# Altium schematic sheet CPU.SchDoc (continued)

## Library data of the parts
C5-C7 C10-C12 C16 C24 = CL10B104KO8NNNC: 16V 100nF X7R ±10% 0603 Multilayer Ceramic Capacitors MLCC - SMD/SMT ROHS; Manufacturer: Samsung Electro-Mechanics; Case Code (Imperial): 0603; Case Code (Metric): 1608; Case/Package: 0603; Category: Ceramic Capacitors; China RoHS: Compliant; Component Kind: Standard; ComponentLink1Description: https://datasheet.octopart.com:443/CL10B104KO8NNNC-Samsung-Electro-Mechanics-datasheet-136482305.pdf?src-supplier=IHS; ComponentLink1URL: https://datasheet.octopart.com:443/CL10B104KO8NNNC-Samsung-Electro-Mechanics-datasheet-136482305.pdf?src-supplier=IHS; ComponentLink2Description: https://datasheet.octopart.com/CL10B104KO8NNNC-Samsung-Electro-Mechanics-datasheet-8324245.pdf; ComponentLink2URL: https://datasheet.octopart.com/CL10B104KO8NNNC-Samsung-Electro-Mechanics-datasheet-8324245.pdf; ComponentLink3Description: https://datasheet.octopart.com/CL10B104KO8NNNC-Samsung-Electro-Mechanics-datasheet-97040617.pdf; ComponentLink3URL: https://datasheet.octopart.com/CL10B104KO8NNNC-Samsung-Electro-Mechanics-datasheet-97040617.pdf; ComponentLink4Description: https://datasheet.octopart.com:443/CL10B104KO8NNNC-Samsung-Electro-Mechanics-datasheet-116890973.pdf?src-supplier=Future+Electronics; ComponentLink4URL: https://datasheet.octopart.com:443/CL10B104KO8NNNC-Samsung-Electro-Mechanics-datasheet-116890973.pdf?src-supplier=Future+Electronics; ComponentLink5Description: https://datasheet.octopart.com:443/CL10B104KO8NNNC-Samsung-Electro-Mechanics-datasheet-81461844.pdf?src-supplier=Future+Electronics; ComponentLink5URL: https://datasheet.octopart.com:443/CL10B104KO8NNNC-Samsung-Electro-Mechanics-datasheet-81461844.pdf?src-supplier=Future+Electronics; ComponentLink6Description: https://datasheet.octopart.com:443/CL10B104KO8NNNC-Samsung-datasheet-42770384.pdf?src-supplier=Future+Electronics; ComponentLink6URL: https://datasheet.octopart.com:443/CL10B104KO8NNNC-Samsung-datasheet-42770384.pdf?src-supplier=Future+Electronics; ComponentLink7Description: https://datasheet.octopart.com:443/CL10B104KO8NNNC-Samsung-datasheet-26589157.pdf?src-supplier=Future+Electronics; ComponentLink7URL: https://datasheet.octopart.com:443/CL10B104KO8NNNC-Samsung-datasheet-26589157.pdf?src-supplier=Future+Electronics; ComponentLink8Description: https://datasheet.octopart.com:443/CL10B104KO8NNNC-Samsung-Electro-Mechanics-datasheet-149136789.pdf?src-supplier=Future+Electronics; ComponentLink8URL: https://datasheet.octopart.com:443/CL10B104KO8NNNC-Samsung-Electro-Mechanics-datasheet-149136789.pdf?src-supplier=Future+Electronics; ComponentLink9Description: https://datasheet.octopart.com:443/CL10B104KO8NNNC-Samsung-datasheet-8326407.pdf?src-supplier=TME; ComponentLink9URL: https://datasheet.octopart.com:443/CL10B104KO8NNNC-Samsung-datasheet-8326407.pdf?src-supplier=TME; ComponentLink10Description: https://datasheet.octopart.com:443/CL10B104KO8NNNC-Samsung-Electro-Mechanics-datasheet-11890603.pdf?src-supplier=Arrow+Electronics; ComponentLink10URL: https://datasheet.octopart.com:443/CL10B104KO8NNNC-Samsung-Electro-Mechanics-datasheet-11890603.pdf?src-supplier=Arrow+Electronics; Contact Plating: Tin; Depth: 800µm; Dielectric: X7R; Dielectric Material: Ceramic; DigiKey: 1276-1005-1-ND; Height: 800µm; Lead Free: Lead Free; Length: 1.6mm; Library Name: Ceramic Capacitors.IntLib; Lifecycle Status: Production; Material: Ceramic; Max Operating Temperature: 125°C; Min Operating Temperature: -55°C; Mounting Technology: Surface Mount; Number of Terminals: 2; P/N: CL10B104KO8NNNC; Pricing: 1=0.1, 10=0.026, 50=0.0172, 100=0.0146, 500=0.0103, 1000=0.00899 (USD); Radiation Hardening: No; REACH SVHC: Yes; RoHS: Compliant; Schedule B: 8532240020, 8532240020|8532240020, 8532240020|8532240020|8532240020, 8532240020|8532240020|8532240020|8532240020; Stock: 2399591; Supplier: DigiKey; Thickness: 889µm; Tolerance: 10%; Voltage: 16V; Voltage Rating: 16V; Voltage Rating (DC): 16V; Width: 800µm
C8 C9 = CL10C180JB81PNC: Ceramic Capacitor, Multilayer, Ceramic, 50V, 5% +Tol, 5% -Tol, C0G, 0+-30ppm/Celcius TC, 18pF, Surface Mount, 0603 inch; Manufacturer: Samsung Electro-Mechanics; Temperature Coefficient: 30ppm/°C; Case Code (Imperial): 0603; Case Code (Metric): 1608; Case/Package: 0603; Category: Ceramic Capacitors; China RoHS: Compliant; Component Kind: Standard; ComponentLink1Description: https://datasheet.octopart.com:443/CL10C180JB81PNC-Samsung-Electro-Mechanics-datasheet-176142735.pdf?src-supplier=IHS; ComponentLink1URL: https://datasheet.octopart.com:443/CL10C180JB81PNC-Samsung-Electro-Mechanics-datasheet-176142735.pdf?src-supplier=IHS; ComponentLink2Description: https://datasheet.octopart.com:443/CL10C180JB81PNC-Samsung-datasheet-26669134.pdf?src-supplier=Future+Electronics; ComponentLink2URL: https://datasheet.octopart.com:443/CL10C180JB81PNC-Samsung-datasheet-26669134.pdf?src-supplier=Future+Electronics; ComponentLink3Description: https://datasheet.octopart.com:443/CL10C180JB81PNC-Samsung-datasheet-12552822.pdf?src-supplier=Future+Electronics; ComponentLink3URL: https://datasheet.octopart.com:443/CL10C180JB81PNC-Samsung-datasheet-12552822.pdf?src-supplier=Future+Electronics; ComponentLink4Description: https://datasheet.octopart.com:443/CL10C180JB81PNC-Samsung-Electro-Mechanics-datasheet-156002628.pdf?src-supplier=Future+Electronics; ComponentLink4URL: https://datasheet.octopart.com:443/CL10C180JB81PNC-Samsung-Electro-Mechanics-datasheet-156002628.pdf?src-supplier=Future+Electronics; ComponentLink5Description: https://datasheet.octopart.com:443/CL10C180JB81PNC-Samsung-datasheet-37081328.pdf?src-supplier=Future+Electronics; ComponentLink5URL: https://datasheet.octopart.com:443/CL10C180JB81PNC-Samsung-datasheet-37081328.pdf?src-supplier=Future+Electronics; ComponentLink6Description: https://datasheet.octopart.com:443/CL10C180JB81PNC-Samsung-Electro-Mechanics-datasheet-11786236.pdf?src-supplier=Arrow+Electronics; ComponentLink6URL: https://datasheet.octopart.com:443/CL10C180JB81PNC-Samsung-Electro-Mechanics-datasheet-11786236.pdf?src-supplier=Arrow+Electronics; Depth: 800µm; Dielectric: C0G; Dielectric Material: Ceramic; DigiKey: 1276-2208-1-ND; Height: 800µm; Lead Free: Lead Free; Length: 1.6mm; Library Name: Ceramic Capacitors.IntLib; Lifecycle Status: Production; Material: Ceramic; Max Operating Temperature: 125°C; Min Operating Temperature: -55°C; Mounting Technology: Surface Mount; Number of Terminals: 2; P/N: CL10C180JB81PNC; Pricing: 1=0.1, 10=0.035, 50=0.0234, 100=0.02, 500=0.01428, 1000=0.01255 (USD); Radiation Hardening: No; Ratings: AEC-Q200; REACH SVHC: Yes; RoHS: Compliant; Schedule B: 8532240020, 8532240020|8532240020|8532240020|8532240020|8532240020; Stock: 34952; Supplier: DigiKey; Thickness: 889µm; Tolerance: 5%; Voltage Rating: 50V; Voltage Rating (DC): 50V; Width: 800µm
C13 C14 C17 = CL21A106KOQNNNE: 16V 10uF X5R ±10% 0805 Multilayer Ceramic Capacitors MLCC - SMD/SMT ROHS; Manufacturer: Samsung Electro-Mechanics; Case Code (Imperial): 0805; Case Code (Metric): 2012; Case/Package: 0805; Category: Ceramic Capacitors; China RoHS: Compliant; Component Kind: Standard; ComponentLink1Description: https://datasheet.octopart.com:443/CL21A106KOQNNNE-Samsung-Electro-Mechanics-datasheet-136031799.pdf?src-supplier=IHS; ComponentLink1URL: https://datasheet.octopart.com:443/CL21A106KOQNNNE-Samsung-Electro-Mechanics-datasheet-136031799.pdf?src-supplier=IHS; ComponentLink2Description: https://datasheet.octopart.com/CL21A106KOQNNNE-Samsung-Electro-Mechanics-datasheet-8324245.pdf; ComponentLink2URL: https://datasheet.octopart.com/CL21A106KOQNNNE-Samsung-Electro-Mechanics-datasheet-8324245.pdf; ComponentLink3Description: https://datasheet.octopart.com/CL21A106KOQNNNE-Samsung-Electro-Mechanics-datasheet-97040617.pdf; ComponentLink3URL: https://datasheet.octopart.com/CL21A106KOQNNNE-Samsung-Electro-Mechanics-datasheet-97040617.pdf; ComponentLink4Description: https://datasheet.octopart.com:443/CL21A106KOQNNNE-Samsung-Electro-Mechanics-datasheet-130012977.pdf?src-supplier=Future+Electronics; ComponentLink4URL: https://datasheet.octopart.com:443/CL21A106KOQNNNE-Samsung-Electro-Mechanics-datasheet-130012977.pdf?src-supplier=Future+Electronics; ComponentLink5Description: https://datasheet.octopart.com:443/CL21A106KOQNNNE-Samsung-Electro-Mechanics-datasheet-82614846.pdf?src-supplier=Future+Electronics; ComponentLink5URL: https://datasheet.octopart.com:443/CL21A106KOQNNNE-Samsung-Electro-Mechanics-datasheet-82614846.pdf?src-supplier=Future+Electronics; ComponentLink6Description: https://datasheet.octopart.com:443/CL21A106KOQNNNE-Samsung-Electro-Mechanics-datasheet-81462122.pdf?src-supplier=Future+Electronics; ComponentLink6URL: https://datasheet.octopart.com:443/CL21A106KOQNNNE-Samsung-Electro-Mechanics-datasheet-81462122.pdf?src-supplier=Future+Electronics; ComponentLink7Description: https://datasheet.octopart.com:443/CL21A106KOQNNNE-Samsung-datasheet-48647542.pdf?src-supplier=Future+Electronics; ComponentLink7URL: https://datasheet.octopart.com:443/CL21A106KOQNNNE-Samsung-datasheet-48647542.pdf?src-supplier=Future+Electronics; ComponentLink8Description: https://datasheet.octopart.com:443/CL21A106KOQNNNE-Samsung-datasheet-26669228.pdf?src-supplier=Future+Electronics; ComponentLink8URL: https://datasheet.octopart.com:443/CL21A106KOQNNNE-Samsung-datasheet-26669228.pdf?src-supplier=Future+Electronics; ComponentLink9Description: https://datasheet.octopart.com:443/CL21A106KOQNNNE-Samsung-Electro-Mechanics-datasheet-149154152.pdf?src-supplier=Future+Electronics; ComponentLink9URL: https://datasheet.octopart.com:443/CL21A106KOQNNNE-Samsung-Electro-Mechanics-datasheet-149154152.pdf?src-supplier=Future+Electronics; ComponentLink10Description: https://datasheet.octopart.com:443/CL21A106KOQNNNE-Samsung-datasheet-22147434.pdf?src-supplier=TME; ComponentLink10URL: https://datasheet.octopart.com:443/CL21A106KOQNNNE-Samsung-datasheet-22147434.pdf?src-supplier=TME; Depth: 1.25mm; Dielectric: X5R; Dielectric Material: Ceramic; DigiKey: 1276-1096-1-ND; Height: 1.4mm; Lead Free: Lead Free; Length: 2mm; Library Name: Ceramic Capacitors.IntLib; Lifecycle Status: Production; Material: Ceramic; Max Operating Temperature: 85°C; Min Operating Temperature: -55°C; Mounting Technology: Surface Mount; Number of Terminals: 2; P/N: CL21A106KOQNNNE; Pricing: 1=0.08, 10=0.021, 50=0.02, 100=0.0192, 500=0.0144, 1000=0.0128 (USD); Radiation Hardening: No; REACH SVHC: Yes; RoHS: Compliant; Schedule B: 8532240020, 8532240020|8532240020|8532240020|8532240020|8532240020|8532240020|8532240020|8532240020|8532240020|8532240020|8532240020|8532240020|8532240020|8532240020|8532240020|8532240020|8532240020|8532240020|8532240020|8532240020|8532240020|8532240020|8532240020|85; Stock: 1352697; Supplier: DigiKey; Thickness: 1.397mm; Tolerance: 10%; Voltage: 16V; Voltage Rating: 16V; Voltage Rating (DC): 16V; Width: 1.25mm
C15 = CL10B105KP8NNNC: Multilayer Ceramic Capacitor, 1 uF, 10 V, ± 10%, X7R, 0603 [1608 Metric]; Manufacturer: Samsung; Material: 4241ConductorMaterial; Voltage Rating: 10V; Case Code (Imperial): 0603; Case Code (Metric): 1608; Case/Package: 0603; Category: Ceramic Capacitors; Component Kind: Standard; Construction: Flat; Depth: 0.8mm; Dielectric: X7R; DigiKey: 1276-1946-1-ND; Height: 0.8mm; Lead Free: Lead Free; Length: 1.6mm; Library Name: Ceramic Capacitors.IntLib; Max Operating Temperature: 125°C; Min Operating Temperature: -55°C; Mounting Technology: Surface Mount; P/N: CL10B105KP8NNNC; Package Quantity: 1; Packaging: Tape and Reel; Pricing: 1=0.1, 10=0.026, 50=0.0146, 100=0.0114, 500=0.00858, 1000=0.00674 (USD); Radiation Hardening: No; RoHS: Yes; RoHS Compliant: Yes; Series: CL; Stock: 7012743; Supplier: Digikey; Thickness: 0.035inch; Tolerance: 10%; Voltage Rating (DC): 10V; Width: 0.031inch
MICRO_SD_CARD = TFP09-2-12B: -25℃~+85℃ 1.85mm Connector and Ejector MicroSD Card (TF Card) Push-Push SMD SD Card / Memory Card Connector ROHS; Component Kind: Standard; Library Name: Connectors.SchLib; P/N: TFP09-2-12B
PROG = TC2050_Full: “No Legs” 10-pin Plug-of-Nails™ Cable fitted with a 10-pin 0.1″ pitch ribbon connector.; Assembly: DNP; Component Kind: Standard; Library Name: Programming Connectors.IntLib
Q1 = PMV30XPAR: Transistor Mosfet P-ch 20V 4.9A 3-PIN SOT-23 T/r; Manufacturer: Nexperia; Manufacturer Lifecycle Status: RELEASED FOR SUPPLY; Drain to Source Resistance: 38mΩ; Max Power Dissipation: 8.3W; Number of Elements: 1; Category: MOSFETs; Component Kind: Standard; ComponentLink1Description: https://datasheet.octopart.com:443/PMV30XPAR-Nexperia-datasheet-180924910.pdf?src-supplier=IHS; ComponentLink1URL: https://datasheet.octopart.com:443/PMV30XPAR-Nexperia-datasheet-180924910.pdf?src-supplier=IHS; ComponentLink2Description: https://datasheet.octopart.com:443/PMV30XPAR-Nexperia-datasheet-138125170.pdf?src-supplier=Future+Electronics; ComponentLink2URL: https://datasheet.octopart.com:443/PMV30XPAR-Nexperia-datasheet-138125170.pdf?src-supplier=Future+Electronics; Contact Plating: Tin; Continuous Drain Current (ID): 4.9A; DigiKey: 1727-PMV30XPARCT-ND; Library Name: Mosfet.IntLib; Lifecycle Status: Production; Max Operating Temperature: 175°C; Min Breakdown Voltage: 20V; Min Operating Temperature: -55°C; Number of Terminals: 3; P/N: PMV30XPAR; Pricing: 1=0.42, 10=0.297, 100=0.1612, 500=0.1604, 1000=0.14598 (USD); REACH SVHC: Yes; RoHS: Compliant; Stock: 10935; Supplier: DigiKey
Q2 = MMBT2222ALT1G: Small Signal Bipolar Transistor, 0.6A I(C), 30V V(BR)CEO, 1-Element, NPN, Silicon, TO-236; Manufacturer: ON Semiconductor; DC Current Gain-Min (hFE): 75; Frequency: 250MHz; Max Collector Current: 600mA; Number of Elements: 1; Package Body Material: Plastic; Power Dissipation-Max (Abs): 225mW; Qualification Status: Not Qualified; Time @ Peak Reflow Temperature-Max (s): 40s; Transistor Application: SWITCHING; Turn-off Time-Max (toff): 285ns; Turn-on Time-Max (ton): 35ns; Category: BJTs; Collector-emitter Voltage-Max: 30V; Component Kind: Standard; ComponentLink1Description: https://datasheet.ciiva.com:443/pdfs/VipMasterIC/IC/ONSM/ONSM-S-A0002809824/ONSM-S-A0002809824-1.pdf?src-supplier=IHS+Markit; ComponentLink1URL: https://datasheet.ciiva.com:443/pdfs/VipMasterIC/IC/ONSM/ONSM-S-A0002809824/ONSM-S-A0002809824-1.pdf?src-supplier=IHS+Markit; ComponentLink2Description: https://datasheet.ciiva.com/976/mmbt2222lt1-d-976368.pdf?src-supplier=Digikey; ComponentLink2URL: https://datasheet.ciiva.com/976/mmbt2222lt1-d-976368.pdf?src-supplier=Digikey; ComponentLink3Description: https://datasheet.ciiva.com/976/mmbt2222lt1-d-976369.pdf?src-supplier=Digikey; ComponentLink3URL: https://datasheet.ciiva.com/976/mmbt2222lt1-d-976369.pdf?src-supplier=Digikey; ComponentLink4Description: https://datasheet.ciiva.com/976/mmbt2222lt1-d-976370.pdf?src-supplier=Digikey; ComponentLink4URL: https://datasheet.ciiva.com/976/mmbt2222lt1-d-976370.pdf?src-supplier=Digikey; ComponentLink5Description: https://datasheet.ciiva.com/4721/mmbt2222lt1-d-80103-4721468.pdf?src-supplier=Mouser; ComponentLink5URL: https://datasheet.ciiva.com/4721/mmbt2222lt1-d-80103-4721468.pdf?src-supplier=Mouser; ComponentLink6Description: https://datasheet.ciiva.com/11198/mmbt2222lt1-d-11198974.pdf?src-supplier=Avnet; ComponentLink6URL: https://datasheet.ciiva.com/11198/mmbt2222lt1-d-11198974.pdf?src-supplier=Avnet; ComponentLink7Description: https://datasheet.ciiva.com/11201/mmbt2222lt1-d-11201758.pdf?src-supplier=Avnet; ComponentLink7URL: https://datasheet.ciiva.com/11201/mmbt2222lt1-d-11201758.pdf?src-supplier=Avnet; ComponentLink8Description: https://datasheet.ciiva.com/15224/getdatasheetpartid-91924-15224358.pdf?src-supplier=Verical; ComponentLink8URL: https://datasheet.ciiva.com/15224/getdatasheetpartid-91924-15224358.pdf?src-supplier=Verical; DigiKey: MMBT2222ALT1GOSTR-ND; JEDEC-95 Code: TO-236; JESD-30 Code: R-PDSO-G3; JESD-609 Code: e3; Library Name: Transistor.IntLib; Max Operating Temperature: 150°C; Moisture Sensitivity Level: 1; P/N: MMBT2222ALT1G; Package Shape: Rectangular; Package Style: SMALL OUTLINEMeter; Peak Reflow Temperature (Cel): 260°C; Pins: 3; Polarity/Channel Type: NPN; Pricing: 1=0.14, 10=0.085, 100=0.0523, 500=0.03782, 1000=0.03311 (USD); Reach SVHC Compliant: Yes; RoHS: Yes; RoHS Compliant: Yes; Stock: 84926; Supplier: Digikey; Surface Mount: Yes; Terminal Finish: Tin; Terminal Form: Gull Wing; Terminal Position: DUAL; Transistor Element Material: SILICON
R1 = ERJ3EKF3300V: RES SMD 330 OHM 1% 1/10W 0603; Manufacturer: Panasonic; Max Power Dissipation: 100mW; Resistance: 330R; Temperature Coefficient: 100ppm/°C; Voltage Rating: 75V; Case Code (Imperial): 0603; Case Code (Metric): 1608; Case/Package: 0603; Component Kind: Standard; ComponentLink1Description: https://datasheet.ciiva.com/48531/aoa0000ce2-48531001.pdf?src-supplier=Avnet; ComponentLink1URL: https://datasheet.ciiva.com/48531/aoa0000ce2-48531001.pdf?src-supplier=Avnet; ComponentLink2Description: https://datasheet.ciiva.com/22721/426256-22721099.pdf?src-supplier=Element14; ComponentLink2URL: https://datasheet.ciiva.com/22721/426256-22721099.pdf?src-supplier=Element14; ComponentLink3Description: https://datasheet.ciiva.com/46373/1678782-46373899.pdf?src-supplier=Element14; ComponentLink3URL: https://datasheet.ciiva.com/46373/1678782-46373899.pdf?src-supplier=Element14; ComponentLink4Description: https://datasheet.ciiva.com/7886/aoa0000ce2-7886729.pdf?src-supplier=Element14; ComponentLink4URL: https://datasheet.ciiva.com/7886/aoa0000ce2-7886729.pdf?src-supplier=Element14; ComponentLink5Description: https://datasheet.ciiva.com/9117/aoa0000ce2-9117132.pdf?src-supplier=Avnet; ComponentLink5URL: https://datasheet.ciiva.com/9117/aoa0000ce2-9117132.pdf?src-supplier=Avnet; ComponentLink6Description: https://datasheet.ciiva.com/46373/1678782-46373900.pdf?src-supplier=Element14; ComponentLink6URL: https://datasheet.ciiva.com/46373/1678782-46373900.pdf?src-supplier=Element14; Composition: Thick Film; Contact Plating: Tin; Depth: 0.8mm; DigiKey: P330HCT-ND; Features: Automotive AEC-Q200; Height: 0.45mm; Lead Free: Lead Free; Length: 1.6mm; Library Name: Resistors.IntLib; Max Operating Temperature: 155°C; Military Standard: Not; Min Operating Temperature: -55°C; Mount: Surface Mount; Number of Pins: 2; Number of Terminations: 2; P/N: ERJ3EKF3300V; Package Quantity: 5000; Packaging: Tape and Reel; Power Rating: 100mW; Pricing 1: 1=0.10000, 10=0.07000, 100=0.02840, 1000=0.01275, 2500=0.01106 (USD); Radiation Hardening: No; REACH SVHC: No SVHC; RoHS: Yes; Stock 1: 1950276; Supplier 1: Digi-Key; Termination: SMD/SMT; Tolerance: 1%; Voltage Rating (DC): 75V; Weight: 7.1E-05oz; Width: 0.8mm
R2 R7 R8 = ERJ-3EKF1002V: Res Thick Film 0603 10K Ohm 1% 1/10W ±100ppm/°C Molded SMD Punched Carrier T/R; Manufacturer: Panasonic; Max Power Dissipation: 100mW; Voltage Rating: 75V; Case Code (Imperial): 0603; Case/Package: 0603; Category: Chip SMD Resistors; China RoHS: Non-Compliant; Component Kind: Standard; ComponentLink1Description: https://datasheet.octopart.com:443/ERJ-3EKF1002V-Panasonic-datasheet-13266541.pdf?src-supplier=Newark; ComponentLink1URL: https://datasheet.octopart.com:443/ERJ-3EKF1002V-Panasonic-datasheet-13266541.pdf?src-supplier=Newark; ComponentLink2Description: https://datasheet.octopart.com:443/ERJ-3EKF1002V-Panasonic-datasheet-5313456.pdf?src-supplier=Newark; ComponentLink2URL: https://datasheet.octopart.com:443/ERJ-3EKF1002V-Panasonic-datasheet-5313456.pdf?src-supplier=Newark; ComponentLink3Description: https://datasheet.octopart.com:443/ERJ-3EKF1002V-Panasonic-datasheet-168681906.pdf?src-supplier=Newark; ComponentLink3URL: https://datasheet.octopart.com:443/ERJ-3EKF1002V-Panasonic-datasheet-168681906.pdf?src-supplier=Newark; ComponentLink4Description: https://datasheet.octopart.com:443/ERJ-3EKF1002V-Panasonic-datasheet-48891056.pdf?src-supplier=Farnell; ComponentLink4URL: https://datasheet.octopart.com:443/ERJ-3EKF1002V-Panasonic-datasheet-48891056.pdf?src-supplier=Farnell; ComponentLink5Description: https://datasheet.octopart.com:443/ERJ-3EKF1002V-Panasonic-datasheet-136608610.pdf?src-supplier=Farnell; ComponentLink5URL: https://datasheet.octopart.com:443/ERJ-3EKF1002V-Panasonic-datasheet-136608610.pdf?src-supplier=Farnell; ComponentLink6Description: https://datasheet.octopart.com:443/ERJ-3EKF1002V-Panasonic-datasheet-10025800.pdf?src-supplier=element14+APAC; ComponentLink6URL: https://datasheet.octopart.com:443/ERJ-3EKF1002V-Panasonic-datasheet-10025800.pdf?src-supplier=element14+APAC; ComponentLink7Description: https://datasheet.octopart.com:443/ERJ-3EKF1002V-Panasonic-datasheet-8853621.pdf?src-supplier=element14+APAC; ComponentLink7URL: https://datasheet.octopart.com:443/ERJ-3EKF1002V-Panasonic-datasheet-8853621.pdf?src-supplier=element14+APAC; ComponentLink8Description: https://datasheet.octopart.com:443/ERJ-3EKF1002V-Panasonic-datasheet-82288794.pdf?src-supplier=Future+Electronics; ComponentLink8URL: https://datasheet.octopart.com:443/ERJ-3EKF1002V-Panasonic-datasheet-82288794.pdf?src-supplier=Future+Electronics; ComponentLink9Description: https://datasheet.octopart.com:443/ERJ-3EKF1002V-Panasonic-datasheet-154766169.pdf?src-supplier=Master+Electronics; ComponentLink9URL: https://datasheet.octopart.com:443/ERJ-3EKF1002V-Panasonic-datasheet-154766169.pdf?src-supplier=Master+Electronics; ComponentLink10Description: https://datasheet.octopart.com:443/ERJ3EKF1002V-Panasonic-datasheet-138819429.pdf?src-supplier=Burklin+Elektronik; ComponentLink10URL: https://datasheet.octopart.com:443/ERJ3EKF1002V-Panasonic-datasheet-138819429.pdf?src-supplier=Burklin+Elektronik; ComponentLink11Description: https://datasheet.octopart.com/ERJ-3EKF1002V-Panasonic-datasheet-78375410.pdf; ComponentLink11URL: https://datasheet.octopart.com/ERJ-3EKF1002V-Panasonic-datasheet-78375410.pdf; ComponentLink12Description: https://datasheet.octopart.com/Precision-Thick-Film-Chip-Resistors-Panasonic-datasheet-family-106.pdf; ComponentLink12URL: https://datasheet.octopart.com/Precision-Thick-Film-Chip-Resistors-Panasonic-datasheet-family-106.pdf; ComponentLink13Description: https://datasheet.octopart.com:443/ERJ-3EKF1002V-Panasonic-datasheet-11542829.pdf?src-supplier=Arrow+Electronics; ComponentLink13URL: https://datasheet.octopart.com:443/ERJ-3EKF1002V-Panasonic-datasheet-11542829.pdf?src-supplier=Arrow+Electronics; ComponentLink14Description: https://datasheet.octopart.com:443/ERJ-3EKF1002V-Panasonic-datasheet-7538305.pdf?src-supplier=Jameco; ComponentLink14URL: https://datasheet.octopart.com:443/ERJ-3EKF1002V-Panasonic-datasheet-7538305.pdf?src-supplier=Jameco; ComponentLink15Description: https://datasheet.octopart.com:443/ERJ-3EKF1002V-Panasonic-datasheet-118621.pdf?src-supplier=DigiKey; ComponentLink15URL: https://datasheet.octopart.com:443/ERJ-3EKF1002V-Panasonic-datasheet-118621.pdf?src-supplier=DigiKey; ComponentLink16Description: https://datasheet.octopart.com:443/ERJ-3EKF1002V-Panasonic-datasheet-41391857.pdf?src-supplier=Electro+Sonic; ComponentLink16URL: https://datasheet.octopart.com:443/ERJ-3EKF1002V-Panasonic-datasheet-41391857.pdf?src-supplier=Electro+Sonic; Composition: Thick Film; Contact Plating: Tin; Depth: 800µm; DigiKey: P10.0KHCT-ND; Features: Automotive AEC-Q200; Height: 450µm; Length: 1.6mm; Library Name: Resistors.IntLib; Lifecycle Status: Production; Max Operating Temperature: 155°C; Military Standard: Not; Min Operating Temperature: -55°C; Mounting Technology: Surface Mount; Number of Terminals: 2; Number of Terminations: 2; P/N: ERJ-3EKF1002V; Packaging: Cut Tape; Pins: 2; Power Dissipation: 100mW; Power Rating: 100mW; Pricing: 1=0.1, 10=0.034, 25=0.0256, 50=0.021, 100=0.0173, 250=0.01364, 500=0.01158, 1000=0.01021 (USD); Radiation Hardening: No; REACH SVHC: Yes; RoHS: Compliant; Schedule B: 8533210045, 8533210045|8533210045|8533210045|8533210045|8533210045|8533210045|8533210045|8533210045|8533210045|8533210045|8533210045|8533210045|8533210045|8533210045|8533210045|8533210045|8533210045|8533210045|8533210045|8533210045|8533210045|8533210045|8533210045|85; Stock: 1304666; Supplier: DigiKey; Temperature Coefficient: 100ppm/°C; Termination: SMD/SMT; Tolerance: 1%; Voltage Rating (DC): 75V; Width: 800µm; Working Voltage: 75V
R3-R5 R16 = ERJ-U03F10R0V: SMD Chip Resistor, 0603 [1608 Metric], 10 ohm, ERJU03 Series, 75 V, Thick Film, 100 mW; Manufacturer: Panasonic; Current Rating: 1A; Power Dissipation: 100mW; Temperature Coefficient: 100ppm/°C; Tolerance: 1%; Voltage Rating: 75V; Case Code (Imperial): 0603; Case Code (Metric): 1608; Case/Package: 0603; Category: Chip SMD Resistors; Component Kind: Standard; ComponentLink1Description: https://datasheet.octopart.com:443/ERJ-U03F10R0V-Panasonic-datasheet-85390793.pdf?src-supplier=Panasonic+SCT; ComponentLink1URL: https://datasheet.octopart.com:443/ERJ-U03F10R0V-Panasonic-datasheet-85390793.pdf?src-supplier=Panasonic+SCT; ComponentLink2Description: https://datasheet.octopart.com:443/ERJ-U03F10R0V-Panasonic-datasheet-61627662.pdf?src-supplier=Farnell; ComponentLink2URL: https://datasheet.octopart.com:443/ERJ-U03F10R0V-Panasonic-datasheet-61627662.pdf?src-supplier=Farnell; ComponentLink3Description: https://datasheet.octopart.com:443/ERJ-U03F10R0V-Panasonic-datasheet-159616995.pdf?src-supplier=Newark; ComponentLink3URL: https://datasheet.octopart.com:443/ERJ-U03F10R0V-Panasonic-datasheet-159616995.pdf?src-supplier=Newark; ComponentLink4Description: https://datasheet.octopart.com:443/ERJ-U03F10R0V-Panasonic-datasheet-11808117.pdf?src-supplier=Newark; ComponentLink4URL: https://datasheet.octopart.com:443/ERJ-U03F10R0V-Panasonic-datasheet-11808117.pdf?src-supplier=Newark; ComponentLink5Description: https://datasheet.octopart.com:443/ERJ-U03F10R0V-Panasonic-datasheet-33006993.pdf?src-supplier=RS+(Formerly+Allied+Electronics); ComponentLink5URL: https://datasheet.octopart.com:443/ERJ-U03F10R0V-Panasonic-datasheet-33006993.pdf?src-supplier=RS+(Formerly+Allied+Electronics); ComponentLink6Description: https://datasheet.octopart.com:443/ERJ-U03F10R0V-Panasonic-datasheet-184398019.pdf?src-supplier=Master+Electronics; ComponentLink6URL: https://datasheet.octopart.com:443/ERJ-U03F10R0V-Panasonic-datasheet-184398019.pdf?src-supplier=Master+Electronics; ComponentLink7Description: https://datasheet.octopart.com:443/ERJU03F10R0V-Panasonic-datasheet-164871545.pdf?src-supplier=Burklin+Elektronik; ComponentLink7URL: https://datasheet.octopart.com:443/ERJU03F10R0V-Panasonic-datasheet-164871545.pdf?src-supplier=Burklin+Elektronik; ComponentLink8Description: https://datasheet.octopart.com/ERJ-U03F10R0V-Panasonic-datasheet-78375410.pdf; ComponentLink8URL: https://datasheet.octopart.com/ERJ-U03F10R0V-Panasonic-datasheet-78375410.pdf; Composition: Thick Film; Contact Plating: Tin; Depth: 800µm; DigiKey: 10-ERJ-U03F10R0VCT-ND; Height: 450µm; Length: 1.6mm; Library Name: Resistors.IntLib; Lifecycle Status: Production; Max Operating Temperature: 155°C; Min Operating Temperature: -55°C; Mounting Technology: Surface Mount; Number of Terminals: 2; P/N: ERJ-U03F10R0V; Packaging: Cut Tape; Power Rating: 100mW; Pricing: 1=0.14, 10=0.066, 25=0.0512, 50=0.043, 100=0.0364, 250=0.0298, 500=0.02602, 1000=0.02304 (USD); REACH SVHC: Yes; RoHS: Compliant; Stock: 7385; Supplier: DigiKey; Termination: SMD/SMT; Voltage Rating (DC): 75V; Weight: 2.012816mg; Width: 800µm; Working Voltage: 75V
R6 = ERJ-3EKF4702V: Res Thick Film 0603 47K Ohm 1% 0.1W ±100ppm/°C Molded SMD Punched Carrier T/R; Manufacturer: Panasonic; Manufacturer Lifecycle Status: ACTIVE; Part Series: Precision Thick Film Chip Resistors; Forward Current: 20mA; Forward Voltage: 2.1V; Illumination Color: Red; Lens Style: Clear; Luminous Intensity: 63mcd; Max Power Dissipation: 100mW; Power Rating: 90mW; Voltage Rating: 75V; Case Code (Imperial): 0603; Case Code (Metric): 1608; Case/Package: 0603; Category: Chip SMD Resistors; China RoHS: Non-Compliant; Color: Red; Component Kind: Standard; ComponentLink1Description: https://datasheet.octopart.com:443/ERJ3EKF4702V-Panasonic-datasheet-182147282.pdf?src-supplier=IHS; ComponentLink1URL: https://datasheet.octopart.com:443/ERJ3EKF4702V-Panasonic-datasheet-182147282.pdf?src-supplier=IHS; ComponentLink2Description: https://datasheet.octopart.com:443/ERJ-3EKF4702V-Panasonic-datasheet-48891056.pdf?src-supplier=Upverter; ComponentLink2URL: https://datasheet.octopart.com:443/ERJ-3EKF4702V-Panasonic-datasheet-48891056.pdf?src-supplier=Upverter; ComponentLink3Description: https://datasheet.octopart.com:443/ERJ-3EKF4702V-Panasonic-datasheet-82288794.pdf?src-supplier=Panasonic; ComponentLink3URL: https://datasheet.octopart.com:443/ERJ-3EKF4702V-Panasonic-datasheet-82288794.pdf?src-supplier=Panasonic; ComponentLink4Description: https://datasheet.octopart.com:443/ERJ-3EKF4702V-Panasonic-datasheet-13266541.pdf?src-supplier=element14+APAC; ComponentLink4URL: https://datasheet.octopart.com:443/ERJ-3EKF4702V-Panasonic-datasheet-13266541.pdf?src-supplier=element14+APAC; ComponentLink5Description: https://datasheet.octopart.com:443/ERJ-3EKF4702V-Panasonic-datasheet-5313456.pdf?src-supplier=element14+APAC; ComponentLink5URL: https://datasheet.octopart.com:443/ERJ-3EKF4702V-Panasonic-datasheet-5313456.pdf?src-supplier=element14+APAC; ComponentLink6Description: https://datasheet.octopart.com:443/ERJ-3EKF4702V-Panasonic-datasheet-10025800.pdf?src-supplier=element14+APAC; ComponentLink6URL: https://datasheet.octopart.com:443/ERJ-3EKF4702V-Panasonic-datasheet-10025800.pdf?src-supplier=element14+APAC; ComponentLink7Description: https://datasheet.octopart.com:443/ERJ-3EKF4702V-Panasonic-datasheet-8853621.pdf?src-supplier=element14+APAC; ComponentLink7URL: https://datasheet.octopart.com:443/ERJ-3EKF4702V-Panasonic-datasheet-8853621.pdf?src-supplier=element14+APAC; ComponentLink8Description: https://datasheet.octopart.com:443/ERJ-3EKF4702V-Panasonic-datasheet-168681906.pdf?src-supplier=Newark; ComponentLink8URL: https://datasheet.octopart.com:443/ERJ-3EKF4702V-Panasonic-datasheet-168681906.pdf?src-supplier=Newark; ComponentLink9Description: https://datasheet.octopart.com:443/ERJ-3EKF4702V-Panasonic-datasheet-136608610.pdf?src-supplier=Farnell; ComponentLink9URL: https://datasheet.octopart.com:443/ERJ-3EKF4702V-Panasonic-datasheet-136608610.pdf?src-supplier=Farnell; ComponentLink10Description: https://datasheet.octopart.com:443/ERJ-3EKF4702V-Panasonic-datasheet-154766169.pdf?src-supplier=Master+Electronics; ComponentLink10URL: https://datasheet.octopart.com:443/ERJ-3EKF4702V-Panasonic-datasheet-154766169.pdf?src-supplier=Master+Electronics; ComponentLink11Description: https://datasheet.octopart.com:443/ERJ3EKF4702V-Panasonic-datasheet-138819429.pdf?src-supplier=Burklin+Elektronik; ComponentLink11URL: https://datasheet.octopart.com:443/ERJ3EKF4702V-Panasonic-datasheet-138819429.pdf?src-supplier=Burklin+Elektronik; ComponentLink12Description: https://datasheet.octopart.com/ERJ-3EKF4702V-Panasonic-datasheet-78375410.pdf; ComponentLink12URL: https://datasheet.octopart.com/ERJ-3EKF4702V-Panasonic-datasheet-78375410.pdf; ComponentLink13Description: https://datasheet.octopart.com/Precision-Thick-Film-Chip-Resistors-Panasonic-datasheet-family-106.pdf; ComponentLink13URL: https://datasheet.octopart.com/Precision-Thick-Film-Chip-Resistors-Panasonic-datasheet-family-106.pdf; ComponentLink14Description: https://datasheet.octopart.com:443/ERJ3EKF4702V-Panasonic-datasheet-11542829.pdf?src-supplier=Arrow+Electronics; ComponentLink14URL: https://datasheet.octopart.com:443/ERJ3EKF4702V-Panasonic-datasheet-11542829.pdf?src-supplier=Arrow+Electronics; ComponentLink15Description: https://datasheet.octopart.com:443/ERJ-3EKF4702V-Panasonic-datasheet-41391857.pdf?src-supplier=Electro+Sonic; ComponentLink15URL: https://datasheet.octopart.com:443/ERJ-3EKF4702V-Panasonic-datasheet-41391857.pdf?src-supplier=Electro+Sonic; Composition: Thick Film; Contact Plating: Tin; Depth: 800µm; Digikey: P47.0KHCT-ND; Features: Automotive AEC-Q200; Height: 450µm; Lead Free: Lead Free; Length: 1.6mm; Library Name: Resistors.IntLib; Lifecycle Status: Production; Max Operating Temperature: 155°C; Military Standard: Not; Min Operating Temperature: -55°C; Mounting Technology: Surface Mount; Number of Terminals: 2; Number of Terminations: 2; P/N: ERJ-3EKF4702V; Packaging: Tape and Reel; Pins: 2; Power Dissipation: 100mW; Pricing: 1=0.09, 10=0.029, 25=0.09, 50=0.0182, 100=0.0149, 250=0.0118, 500=0.010, 1000=0.00859 (EUR); Radiation Hardening: No; REACH SVHC: Yes; RoHS: Compliant; Schedule B: 8533210045, 8533210045|8533210045|8533210045|8533210045|8533210045|8533210045|8533210045|8533210045|8533210045|8533210045|8533210045|8533210045|8533210045|8533210045|8533210045|8533210045|8533210045|8533210045|8533210045|8533210045|8533210045|8533210045|8533210045|85; Stock: 85607; Supplier: DigiKey; Temperature Coefficient: 100ppm/°C; Termination: SMD/SMT; Tolerance: 1%; Voltage Rating (DC): 75V; Width: 800µm; Working Voltage: 75V
R9 = ERJ-U03F1001V: Resistor, thick film, SMD 0603 (1608), 1 kΩ, 0.1 W, ±1 %, ERJU03F1001V; Manufacturer: Panasonic; Manufacturer Lifecycle Status: ACTIVE; Part Series: Anti-Sulfurated Thick Film Chip Resistors; Current Rating: 1A; Power Dissipation: 100mW; Temperature Coefficient: 100ppm/°C; Tolerance: 1%; Voltage Rating: 75V; Case Code (Imperial): 0603; Case Code (Metric): 1608; Case/Package: 0603; Category: Chip SMD Resistors; Component Kind: Standard; ComponentLink1Description: https://datasheet.octopart.com:443/ERJ-U03F1001V-Panasonic-datasheet-85390793.pdf?src-supplier=Panasonic; ComponentLink1URL: https://datasheet.octopart.com:443/ERJ-U03F1001V-Panasonic-datasheet-85390793.pdf?src-supplier=Panasonic; ComponentLink2Description: https://datasheet.octopart.com:443/ERJ-U03F1001V-Panasonic-datasheet-11808117.pdf?src-supplier=RS+(Formerly+Allied+Electronics); ComponentLink2URL: https://datasheet.octopart.com:443/ERJ-U03F1001V-Panasonic-datasheet-11808117.pdf?src-supplier=RS+(Formerly+Allied+Electronics); ComponentLink3Description: https://datasheet.octopart.com:443/ERJ-U03F1001V-Panasonic-datasheet-61627662.pdf?src-supplier=Farnell; ComponentLink3URL: https://datasheet.octopart.com:443/ERJ-U03F1001V-Panasonic-datasheet-61627662.pdf?src-supplier=Farnell; ComponentLink4Description: https://datasheet.octopart.com:443/ERJ-U03F1001V-Panasonic-datasheet-184398019.pdf?src-supplier=Electro+Sonic; ComponentLink4URL: https://datasheet.octopart.com:443/ERJ-U03F1001V-Panasonic-datasheet-184398019.pdf?src-supplier=Electro+Sonic; ComponentLink5Description: https://datasheet.octopart.com:443/ERJ-U03F1001V-Panasonic-datasheet-159616995.pdf?src-supplier=Newark; ComponentLink5URL: https://datasheet.octopart.com:443/ERJ-U03F1001V-Panasonic-datasheet-159616995.pdf?src-supplier=Newark; ComponentLink6Description: https://datasheet.octopart.com:443/ERJ-U03F1001V-Panasonic-datasheet-33006993.pdf?src-supplier=Newark; ComponentLink6URL: https://datasheet.octopart.com:443/ERJ-U03F1001V-Panasonic-datasheet-33006993.pdf?src-supplier=Newark; ComponentLink7Description: https://datasheet.octopart.com:443/ERJU03F1001V-Panasonic-datasheet-164871545.pdf?src-supplier=Burklin+Elektronik; ComponentLink7URL: https://datasheet.octopart.com:443/ERJU03F1001V-Panasonic-datasheet-164871545.pdf?src-supplier=Burklin+Elektronik; ComponentLink8Description: https://datasheet.octopart.com/ERJ-U03F1001V-Panasonic-datasheet-78375410.pdf; ComponentLink8URL: https://datasheet.octopart.com/ERJ-U03F1001V-Panasonic-datasheet-78375410.pdf; Composition: Thick Film; Contact Plating: Tin; Depth: 800µm; DigiKey: 10-ERJ-U03F1001VCT-ND; Height: 450µm; Length: 1.6mm; Library Name: Resistors.IntLib; Lifecycle Status: Production; Max Operating Temperature: 155°C; Min Operating Temperature: -55°C; Mounting Technology: Surface Mount; Number of Terminals: 2; P/N: ERJ-U03F1001V; Packaging: Cut Tape; Power Rating: 100mW; Pricing: 1=0.12, 10=0.057, 25=0.12, 50=0.0372, 100=0.0312, 250=0.02572, 500=0.02248, 1000=0.0199 (EUR); REACH SVHC: Yes; RoHS: Compliant; Stock: 30734; Supplier: DigiKey; Termination: SMD/SMT; Voltage Rating (DC): 75V; Weight: 2.012816mg; Width: 800µm; Working Voltage: 75V
RN1 RN3 = EXB-38V103JV: Res Thick Film Array 1206 10K Ohm 5% ±200ppm/°C ISOL 8-Pin Convex SMD Punched Carrier T/R; Manufacturer: Panasonic; Circuit Type: Isolated; Number of Circuits: 4; Number of Contacts: 8; Number of Elements: 1; Power Dissipation: 63mW; Power Per Element: 62.5mW; Voltage Rating: 50V; Case Code (Imperial): 1206; Case Code (Metric): 3216; Case/Package: 1206; Category: Resistor Arrays; Component Kind: Standard; ComponentLink1Description: https://datasheet.octopart.com:443/EXB-38V103JV-Panasonic-datasheet-138897389.pdf?src-supplier=Upverter; ComponentLink1URL: https://datasheet.octopart.com:443/EXB-38V103JV-Panasonic-datasheet-138897389.pdf?src-supplier=Upverter; ComponentLink2Description: https://sigma.octopart.com:443/138766958/technical_drawing/Panasonic-EXB-38V103JV.pdf?src-supplier=Upverter; ComponentLink2URL: https://sigma.octopart.com:443/138766958/technical_drawing/Panasonic-EXB-38V103JV.pdf?src-supplier=Upverter; ComponentLink3Description: https://datasheet.octopart.com:443/EXB-38V103JV-Panasonic-datasheet-13266625.pdf?src-supplier=RS+(Formerly+Allied+Electronics); ComponentLink3URL: https://datasheet.octopart.com:443/EXB-38V103JV-Panasonic-datasheet-13266625.pdf?src-supplier=RS+(Formerly+Allied+Electronics); ComponentLink4Description: https://datasheet.octopart.com:443/EXB-38V103JV-Panasonic-datasheet-41578787.pdf?src-supplier=Panasonic+SCT; ComponentLink4URL: https://datasheet.octopart.com:443/EXB-38V103JV-Panasonic-datasheet-41578787.pdf?src-supplier=Panasonic+SCT; ComponentLink5Description: https://datasheet.octopart.com:443/EXB-38V103JV-Panasonic-datasheet-136608612.pdf?src-supplier=Newark; ComponentLink5URL: https://datasheet.octopart.com:443/EXB-38V103JV-Panasonic-datasheet-136608612.pdf?src-supplier=Newark; ComponentLink6Description: https://datasheet.octopart.com:443/EXB-38V103JV-Panasonic-datasheet-10404292.pdf?src-supplier=Newark; ComponentLink6URL: https://datasheet.octopart.com:443/EXB-38V103JV-Panasonic-datasheet-10404292.pdf?src-supplier=Newark; ComponentLink7Description: https://datasheet.octopart.com:443/EXB-38V103JV-Panasonic-datasheet-22087674.pdf?src-supplier=Newark; ComponentLink7URL: https://datasheet.octopart.com:443/EXB-38V103JV-Panasonic-datasheet-22087674.pdf?src-supplier=Newark; ComponentLink8Description: https://datasheet.octopart.com:443/EXB-38V103JV-Panasonic-datasheet-154766207.pdf?src-supplier=Master+Electronics; ComponentLink8URL: https://datasheet.octopart.com:443/EXB-38V103JV-Panasonic-datasheet-154766207.pdf?src-supplier=Master+Electronics; ComponentLink9Description: https://datasheet.octopart.com:443/EXB-38V103JV-Panasonic-datasheet-38538506.pdf?src-supplier=Electro+Sonic; ComponentLink9URL: https://datasheet.octopart.com:443/EXB-38V103JV-Panasonic-datasheet-38538506.pdf?src-supplier=Electro+Sonic; ComponentLink10Description: https://datasheet.octopart.com:443/EXB38V103JV-Panasonic-datasheet-184959162.pdf?src-supplier=Electro+Sonic; ComponentLink10URL: https://datasheet.octopart.com:443/EXB38V103JV-Panasonic-datasheet-184959162.pdf?src-supplier=Electro+Sonic; ComponentLink11Description: https://datasheet.octopart.com:443/EXB38V103JV-Panasonic-datasheet-27908906.pdf?src-supplier=Electro+Sonic; ComponentLink11URL: https://datasheet.octopart.com:443/EXB38V103JV-Panasonic-datasheet-27908906.pdf?src-supplier=Electro+Sonic; ComponentLink12Description: https://datasheet.octopart.com:443/EXB38V103JV-Panasonic-datasheet-188913051.pdf?src-supplier=TME; ComponentLink12URL: https://datasheet.octopart.com:443/EXB38V103JV-Panasonic-datasheet-188913051.pdf?src-supplier=TME; ComponentLink13Description: https://datasheet.octopart.com/EXB-38V103JV-Panasonic-datasheet-78375410.pdf; ComponentLink13URL: https://datasheet.octopart.com/EXB-38V103JV-Panasonic-datasheet-78375410.pdf; ComponentLink14Description: https://datasheet.octopart.com:443/EXB-38V103JV-Panasonic---ECG-datasheet-15994758.pdf?src-supplier=Farnell; ComponentLink14URL: https://datasheet.octopart.com:443/EXB-38V103JV-Panasonic---ECG-datasheet-15994758.pdf?src-supplier=Farnell; ComponentLink15Description: https://datasheet.octopart.com:443/EXB-38V103JV-Panasonic-datasheet-11546899.pdf?src-supplier=Arrow+Electronics; ComponentLink15URL: https://datasheet.octopart.com:443/EXB-38V103JV-Panasonic-datasheet-11546899.pdf?src-supplier=Arrow+Electronics; ComponentLink16Description: https://datasheet.octopart.com:443/EXB-38V103JV-Panasonic-datasheet-7277236.pdf?src-supplier=Jameco; ComponentLink16URL: https://datasheet.octopart.com:443/EXB-38V103JV-Panasonic-datasheet-7277236.pdf?src-supplier=Jameco; ComponentLink17Description: https://datasheet.octopart.com:443/EXB-38V103JV-Panasonic-datasheet-118644.pdf?src-supplier=DigiKey; ComponentLink17URL: https://datasheet.octopart.com:443/EXB-38V103JV-Panasonic-datasheet-118644.pdf?src-supplier=DigiKey; Composition: Thick Film; Contact Plating: Tin; Depth: 1.6mm; DigiKey: Y9103CT-ND; Height: 500µm; Lead Free: Lead Free; Length: 3.2mm; Library Name: Resistors Array.IntLib; Lifecycle Status: Production; Max Operating Temperature: 125°C; Military Standard: Not; Min Operating Temperature: -55°C; Mounting Technology: Surface Mount; Number of Resistors: 4; Number of Terminals: 8; P/N: EXB-38V103JV; Packaging: Cut Tape; Pins: 8; Pricing: 1=0.1, 10=0.051, 25=0.0428, 50=0.0376, 100=0.0331, 250=0.02816, 500=0.02504, 1000=0.02239 (USD); Radiation Hardening: No; REACH SVHC: Yes; RoHS: Compliant; Stock: 234882; Supplier: DigiKey; Temperature Coefficient: 200ppm/°C; Terminal Pitch: 800µm; Termination: Convex; Tolerance: 5%; Width: 1.6mm; Working Voltage: 50V
RN2 = EXB-38V102JV: Res Thick Film Array 1K Ohm 5% ±200ppm/°C ISOL 8-Pin 1206; Manufacturer: Panasonic; Circuit Type: Isolated; Number of Circuits: 4; Number of Contacts: 8; Number of Elements: 1; Power Dissipation: 63mW; Power Per Element: 62.5mW; Power Rating: 252mW; Voltage Rating: 50V; Case Code (Imperial): 1206; Case Code (Metric): 3216; Category: Resistor Arrays; Component Kind: Standard; ComponentLink1Description: https://datasheet.octopart.com:443/EXB-38V102JV-Panasonic-datasheet-41578787.pdf?src-supplier=Future+Electronics; ComponentLink1URL: https://datasheet.octopart.com:443/EXB-38V102JV-Panasonic-datasheet-41578787.pdf?src-supplier=Future+Electronics; ComponentLink2Description: https://datasheet.octopart.com:443/EXB-38V102JV-Panasonic-datasheet-13266625.pdf?src-supplier=RS+(Formerly+Allied+Electronics); ComponentLink2URL: https://datasheet.octopart.com:443/EXB-38V102JV-Panasonic-datasheet-13266625.pdf?src-supplier=RS+(Formerly+Allied+Electronics); ComponentLink3Description: https://datasheet.octopart.com:443/EXB-38V102JV-Panasonic-datasheet-136608612.pdf?src-supplier=Farnell; ComponentLink3URL: https://datasheet.octopart.com:443/EXB-38V102JV-Panasonic-datasheet-136608612.pdf?src-supplier=Farnell; ComponentLink4Description: https://datasheet.octopart.com:443/EXB-38V102JV-Panasonic---ECG-datasheet-15994758.pdf?src-supplier=Farnell; ComponentLink4URL: https://datasheet.octopart.com:443/EXB-38V102JV-Panasonic---ECG-datasheet-15994758.pdf?src-supplier=Farnell; ComponentLink5Description: https://datasheet.octopart.com:443/EXB-38V102JV-Panasonic-datasheet-10404292.pdf?src-supplier=Newark; ComponentLink5URL: https://datasheet.octopart.com:443/EXB-38V102JV-Panasonic-datasheet-10404292.pdf?src-supplier=Newark; ComponentLink6Description: https://datasheet.octopart.com:443/EXB-38V102JV-Panasonic-datasheet-22087674.pdf?src-supplier=Newark; ComponentLink6URL: https://datasheet.octopart.com:443/EXB-38V102JV-Panasonic-datasheet-22087674.pdf?src-supplier=Newark; ComponentLink7Description: https://datasheet.octopart.com:443/EXB-38V102JV-Panasonic-datasheet-154766207.pdf?src-supplier=Master+Electronics; ComponentLink7URL: https://datasheet.octopart.com:443/EXB-38V102JV-Panasonic-datasheet-154766207.pdf?src-supplier=Master+Electronics; ComponentLink8Description: https://datasheet.octopart.com:443/EXB-38V102JV-Panasonic-datasheet-38538506.pdf?src-supplier=Electro+Sonic; ComponentLink8URL: https://datasheet.octopart.com:443/EXB-38V102JV-Panasonic-datasheet-38538506.pdf?src-supplier=Electro+Sonic; ComponentLink9Description: https://datasheet.octopart.com:443/EXB38V102JV-Panasonic-datasheet-27908906.pdf?src-supplier=Electro+Sonic; ComponentLink9URL: https://datasheet.octopart.com:443/EXB38V102JV-Panasonic-datasheet-27908906.pdf?src-supplier=Electro+Sonic; ComponentLink10Description: https://datasheet.octopart.com:443/EXB38V102JV-Panasonic-datasheet-188913051.pdf?src-supplier=TME; ComponentLink10URL: https://datasheet.octopart.com:443/EXB38V102JV-Panasonic-datasheet-188913051.pdf?src-supplier=TME; ComponentLink11Description: https://datasheet.octopart.com/EXB-38V102JV-Panasonic-datasheet-78375410.pdf; ComponentLink11URL: https://datasheet.octopart.com/EXB-38V102JV-Panasonic-datasheet-78375410.pdf; ComponentLink12Description: https://datasheet.octopart.com/Thick-Film-Chip-Resistor-Networks-%7C-EXB-Panasonic-datasheet-family-112.pdf; ComponentLink12URL: https://datasheet.octopart.com/Thick-Film-Chip-Resistor-Networks-%7C-EXB-Panasonic-datasheet-family-112.pdf; ComponentLink13Description: https://datasheet.octopart.com:443/EXB-38V102JV-Panasonic-datasheet-11546899.pdf?src-supplier=Arrow+Electronics; ComponentLink13URL: https://datasheet.octopart.com:443/EXB-38V102JV-Panasonic-datasheet-11546899.pdf?src-supplier=Arrow+Electronics; ComponentLink14Description: https://datasheet.octopart.com:443/EXB-38V102JV-Panasonic-datasheet-7279555.pdf?src-supplier=Jameco; ComponentLink14URL: https://datasheet.octopart.com:443/EXB-38V102JV-Panasonic-datasheet-7279555.pdf?src-supplier=Jameco; ComponentLink15Description: https://datasheet.octopart.com:443/EXB-38V102JV-Panasonic-datasheet-118644.pdf?src-supplier=DigiKey; ComponentLink15URL: https://datasheet.octopart.com:443/EXB-38V102JV-Panasonic-datasheet-118644.pdf?src-supplier=DigiKey; Contact Plating: Tin; Depth: 1.6mm; DigiKey: Y9102CT-ND; Height: 500µm; Lead Free: Lead Free; Length: 3.2mm; Library Name: Resistors Array.IntLib; Lifecycle Status: Production; Max Operating Temperature: 125°C; Military Standard: Not; Min Operating Temperature: -55°C; Mounting Technology: Surface Mount; Number of Resistors: 4; Number of Terminals: 8; P/N: EXB-38V102JV; Packaging: Cut Tape; Pins: 8; Pricing: 1=0.1, 10=0.051, 25=0.0428, 50=0.0376, 100=0.0331, 250=0.02816, 500=0.02504, 1000=0.02239 (USD); Radiation Hardening: No; REACH SVHC: Yes; RoHS: Compliant; Stock: 51454; Supplier: DigiKey; Temperature Coefficient: 200ppm/°C; Terminal Pitch: 800µm; Termination: Convex; Tolerance: 5%; Voltage Rating (DC): 50V; Width: 1.6mm; Working Voltage: 50V
RN4 = EXB-38V473JV: Res Thick Film Array 47K Ohm 5% ±200ppm/°C ISOL Molded 8-Pin 1206(4 X 0603) Convex SMD Punched Carrier T/R; Manufacturer: Panasonic; Voltage Rating (DC): 100V; Category: Resistor Arrays; Component Kind: Standard; ComponentLink1Description: https://datasheet.ciiva.com/26928/getdatasheetpartid-253121-26928884.pdf?src-supplier=Verical; ComponentLink1URL: https://datasheet.ciiva.com/26928/getdatasheetpartid-253121-26928884.pdf?src-supplier=Verical; ComponentLink2Description: https://datasheet.ciiva.com/46376/1480003-46376286.pdf?src-supplier=Element14; ComponentLink2URL: https://datasheet.ciiva.com/46376/1480003-46376286.pdf?src-supplier=Element14; ComponentLink3Description: https://datasheet.ciiva.com/28637/1790599-28637082.pdf?src-supplier=Element14; ComponentLink3URL: https://datasheet.ciiva.com/28637/1790599-28637082.pdf?src-supplier=Element14; ComponentLink4Description: https://datasheet.ciiva.com/46388/1480003-46388007.pdf?src-supplier=Element14; ComponentLink4URL: https://datasheet.ciiva.com/46388/1480003-46388007.pdf?src-supplier=Element14; ComponentLink5Description: https://datasheet.ciiva.com/30055/aoc0000ce1-30055519.pdf?src-supplier=Onlinecomponents.com; ComponentLink5URL: https://datasheet.ciiva.com/30055/aoc0000ce1-30055519.pdf?src-supplier=Onlinecomponents.com; ComponentLink6Description: https://datasheet.ciiva.com/5735/1480003-5735223.pdf?src-supplier=Farnell; ComponentLink6URL: https://datasheet.ciiva.com/5735/1480003-5735223.pdf?src-supplier=Farnell; DigiKey: Y9473CT-ND; Lead Free: 5697LeadFree; Library Name: Resistors Array.IntLib; P/N: EXB-38V473JV; Pricing: 1=0.1, 10=0.052, 25=0.0436, 50=0.0384, 100=0.0338, 250=0.02872, 500=0.02554, 1000=0.02283 (USD); RoHS: Yes; Stock: 82082; Supplier: Digikey; Thickness: 500um
STATUS = APT1608QBC/D: Single Color LED, Blue, Water Clear, 1.2mm; Manufacturer: Kingbright; Channels: 1; Forward Current: 30mA; Luminous Intensity-Nom: 100mcd; Overall Height: 0.75mm; Shape: Rectangular; Viewing Angle: 120°; Category: LEDs; Component Kind: Standard; ComponentLink1Description: https://datasheet.ciiva.com:443/pdfs/VipMasterIC/IC/KNGB/KNGBS06436/KNGBS06436-1.pdf?src-supplier=IHS+Markit; ComponentLink1URL: https://datasheet.ciiva.com:443/pdfs/VipMasterIC/IC/KNGB/KNGBS06436/KNGBS06436-1.pdf?src-supplier=IHS+Markit; ComponentLink2Description: https://datasheet.ciiva.com/12403/apt1608qbc-d-65281-12403861.pdf?src-supplier=Mouser; ComponentLink2URL: https://datasheet.ciiva.com/12403/apt1608qbc-d-65281-12403861.pdf?src-supplier=Mouser; ComponentLink3Description: https://datasheet.ciiva.com/2121/apt1608qbc-d-2121092.pdf?src-supplier=Digikey; ComponentLink3URL: https://datasheet.ciiva.com/2121/apt1608qbc-d-2121092.pdf?src-supplier=Digikey; ComponentLink4Description: https://datasheet.ciiva.com/2121/apt1608qbc-d-2121093.pdf?src-supplier=Digikey; ComponentLink4URL: https://datasheet.ciiva.com/2121/apt1608qbc-d-2121093.pdf?src-supplier=Digikey; ComponentLink5Description: https://datasheet.ciiva.com/2121/apt1608qbc-d-2121094.pdf?src-supplier=Digikey; ComponentLink5URL: https://datasheet.ciiva.com/2121/apt1608qbc-d-2121094.pdf?src-supplier=Digikey; DigiKey: 754-1434-1-ND; Lens Style: WATER CLEAR; Library Name: LEDs.IntLib; Max Operating Temperature: 85°C; Min Operating Temperature: -40°C; Mounting Technology: Surface Mount; Optoelectronic Device Type: SINGLE COLOR LED; P/N: APT1608QBC/D; Packaging: Tape and Reel; Pins: 2; Pricing: 1=0.43, 10=0.263, 100=0.151, 1000=0.11817 (USD); RoHS: Yes; RoHS Compliant: Yes; Size: 1.2mm; Stock: 112434; Supplier: Digikey; Terminal Pitch: 1.65mm
U2 = STM32H562RGT6: RISC Microcontroller, 32-Bit, FLASH, CORTEX-M33 CPU, 250MHz, CMOS, PQFP64; Manufacturer: STMicroelectronics; ADC Channels (Resolution): 16-Ch 12-Bit; Clock Frequency: 50MHz; DAC Channels (Resolution): 2-Ch 12-Bit; EEPROM Memory Size: 0B; Interface: CAN, USB; Max Supply Current: 164mA; Max Supply Voltage: 3.6V; Min Supply Voltage: 1.71V; Number of I/Os: 12; Number of Timers/Counters: 22; Operating Supply Voltage: 3V; Peripherals: POR; RAM Size: 644kB; Category: Microcontrollers; China RoHS: Compliant; Component Kind: Standard; ComponentLink1Description: https://datasheet.octopart.com:443/STM32H562RGT6-STMicroelectronics-datasheet-181247490.pdf?src-supplier=TME; ComponentLink1URL: https://datasheet.octopart.com:443/STM32H562RGT6-STMicroelectronics-datasheet-181247490.pdf?src-supplier=TME; DigiKey: 497-STM32H562RGT6-ND; Height - Seated (Max): 1.6mm; Length: 10mm; Library Name: Microcontrollers.IntLib; Lifecycle Status: Production; Max Operating Temperature: 85°C; Memory Format: FLASH; Min Operating Temperature: -40°C; Number of Terminals: 64; P/N: STM32H562RGT6; Pricing: 1=5.98, 10=4.584, 25=4.2348, 100=3.8516, 250=3.66872, 500=3.55856, 1000=3.46787, 2500=3.37213 (USD); REACH SVHC: Yes; RoHS: Compliant; Stock: 614; Supplier: DigiKey; Terminal Pitch: 500µm; Width: 10mm
Y1 = ECS-80-18-30-JGN-TR: Crystal 8MHz ±20ppm (Tol) ±30ppm (Stability) 18pF FUND 100Ohm 2-Pin Mini-SMD T/R; Manufacturer: ECS International; ESR (Equivalent Series Resistance): 100R; Case/Package: SMD/SMT; Category: Crystals; Component Kind: Standard; ComponentLink1Description: http://www.mouser.com/ds/2/122/ecx-53r-17605.pdf?src-supplier=Mouser; ComponentLink1URL: http://www.mouser.com/ds/2/122/ecx-53r-17605.pdf?src-supplier=Mouser; ComponentLink2Description: http://www.ecsxtal.com/store/pdf/partnumber4.pdf?src-supplier=Digikey; ComponentLink2URL: http://www.ecsxtal.com/store/pdf/partnumber4.pdf?src-supplier=Digikey; ComponentLink3Description: http://www.ecsxtal.com/store/pdf/ecx-53r.pdf?src-supplier=Digikey; ComponentLink3URL: http://www.ecsxtal.com/store/pdf/ecx-53r.pdf?src-supplier=Digikey; ComponentLink4Description: https://datasheet.octopart.com/ECS-80-18-30-JGN-TR-ECS-International-datasheet-145012643.pdf?src-supplier=Electro+Sonic; ComponentLink4URL: https://datasheet.octopart.com/ECS-80-18-30-JGN-TR-ECS-International-datasheet-145012643.pdf?src-supplier=Electro+Sonic; DigiKey: XC2103CT-ND; Frequency Stability: 30ppm; Frequency Tolerance: 20ppm; Library Name: Crystals.IntLib; Load Capacitance: 18pF; Max Operating Temperature: 85°C; Min Operating Temperature: -40°C; Mounting Technology: Surface Mount; P/N: ECS-80-18-30-JGN-TR; Packaging: Cut Tape; Pricing: 1=0.73, 10=0.634, 50=0.5746, 100=0.5508, 500=0.49936 (USD); RoHS: Yes; RoHS Compliant: Yes; Series: ECX-53; Stock: 39213; Supplier: Digikey
